# Altium schematic sheet Control.SchDoc
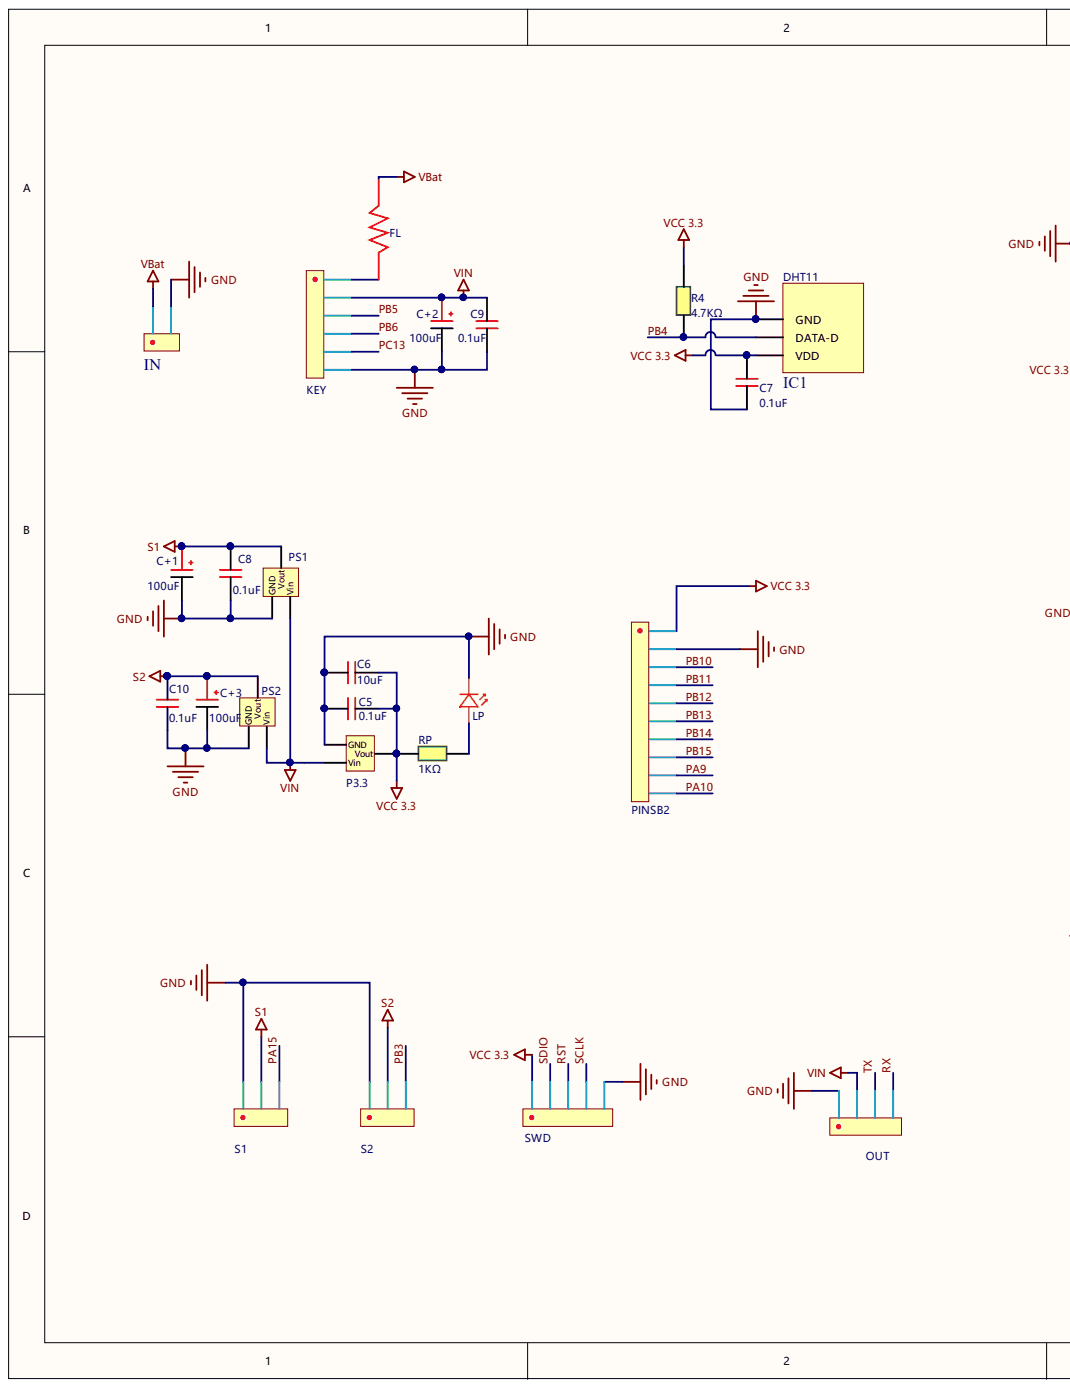
[diagram p0: Control.SchDoc, left]
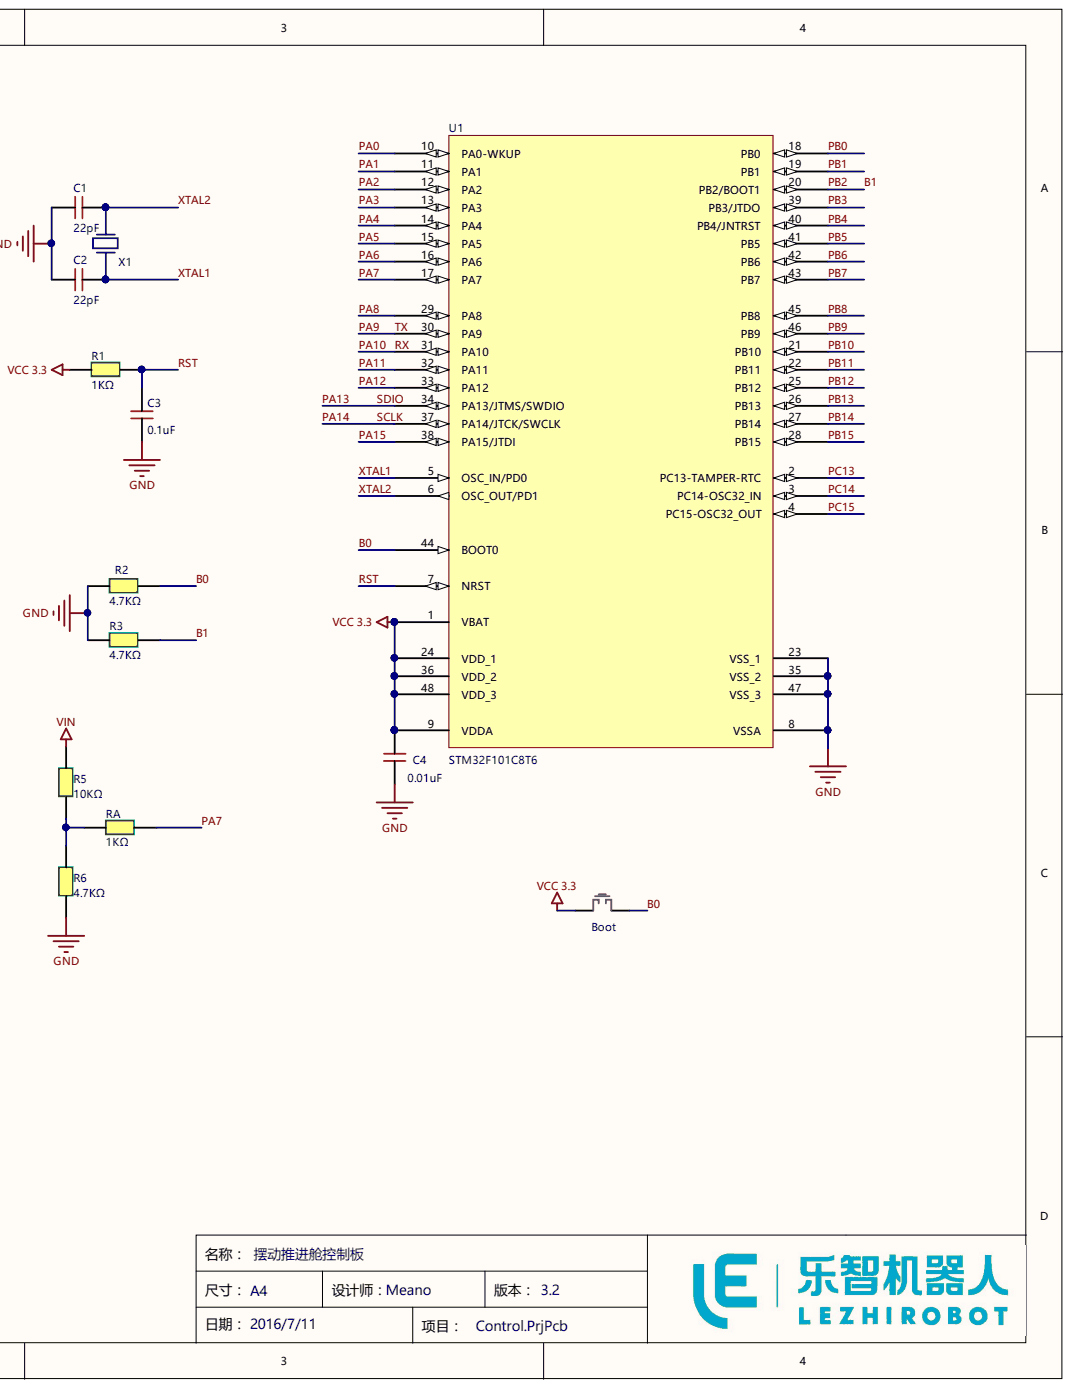
[diagram p1: Control.SchDoc, right]

## Parts
Boot  Key  [KEY]  (value not drawn)
C1  value 22pF  [Cap - 0805]  (hidden comment: Cap)
C2  value 22pF  [Cap - 0805]  (hidden comment: Cap)
C3  value 0.1uF  [Cap - 0805]  (hidden comment: Cap)
C4  value 0.01uF  [Cap - 0805]  (hidden comment: Cap)
C5  value 0.1uF  [Cap - 0805]  (hidden comment: Cap)
C6  value 10uF  [Cap - 0805]  (hidden comment: Cap)
C7  value 0.1uF  [Cap - 0805]  (hidden comment: Cap)
C8  value 0.1uF  [Cap - 0805]  (hidden comment: Cap)
C9  value 0.1uF  [Cap - 0805]  (hidden comment: Cap)
C10  value 0.1uF  [Cap - 0805]  (hidden comment: Cap)
C+1  value 100uF  [SMD-EC-F6.3]  (hidden comment: CapPlus)
C+2  value 100uF  [SMD-EC-F6.3]  (hidden comment: CapPlus)
C+3  value 100uF  [SMD-EC-F6.3]  (hidden comment: CapPlus)
DHT11  IC1  [DHT11]
FL  Fuse  [Fuse-1812]  (value not drawn)
IN  PINS2  [PINS2-Bat]  (value not drawn)
KEY  PH2.0-6P  [PH2.0-6P]  (value not drawn)
LP  LED Light  [LED - 0805]  (value not drawn)
OUT  PH2.0-4P  [PH2.0-4P]  (value not drawn)
P3.3  PowerIC  [ASM1117]  (value not drawn)
PINSB2  PINS10  [PINS10-2mm -SMD]  (value not drawn)
PS1  PowerIC  [ASM1117]  (value not drawn)
PS2  PowerIC  [ASM1117]  (value not drawn)
R1  value 1KΩ  [Res - 0805]  (hidden comment: Res)
R2  value 4.7KΩ  [Res - 0805]  (hidden comment: Res)
R3  value 4.7KΩ  [Res - 0805]  (hidden comment: Res)
R4  value 4.7KΩ  [Res - 0805]  (hidden comment: Res)
R5  value 10KΩ  [Res - 0805]  (hidden comment: Res)
R6  value 4.7KΩ  [Res - 0805]  (hidden comment: Res)
RA  value 1KΩ  [Res - 0805]  (hidden comment: Res)
RP  value 1KΩ  [Res - 0805]  (hidden comment: Res)
S1  PH2.0-3P  [PH2.0-3P]  (value not drawn)
S2  PH2.0-3P  [PH2.0-3P]  (value not drawn)
SWD  PH2.0-5P  [PH2.0-5P]  (value not drawn)
U1  STM32F101C8T6  [LQFP48_N]
X1  XTAL  [XTAL SMD]  (value not drawn)

## Nets
GND: C1.2 C2.2 C3.1 C4.1 C5.1 C6.1 C7.1 C8.2 C9.2 C10.1 C+1.2 C+2.2 C+3.2 DHT11.4 IN.2 KEY.6 LP.2 OUT.1 P3.3.1 PINSB2.2 PS1.1 PS2.1 R2.1 R3.1 R6.1 S1.1 S2.1 SWD.5 U1.8(VSSA) U1.23(VSS_1) U1.35(VSS_2) U1.47(VSS_3)
S1: C8.1 C+1.1 PS1.2 S1.2
S2: C10.2 C+3.1 PS2.2 S2.2
VBAT: FL.2 IN.1
VCC 3.3: Boot.2 C4.2 C5.2 C6.2 C7.2 DHT11.1 P3.3.2 PINSB2.1 R1.1 R4.2 RP.2 SWD.1 U1.1(VBAT) U1.9(VDDA) U1.24(VDD_1) U1.36(VDD_2) U1.48(VDD_3)
VIN: C9.1 C+2.1 KEY.2 OUT.2 P3.3.3 PS1.3 PS2.3 R5.2
B0: Boot.1 R2.2 U1.44(BOOT0)
B1: R3.2 U1.20(PB2/BOOT1)
PA0: U1.10(PA0-WKUP)
PA1: U1.11(PA1)
PA2: U1.12(PA2)
PA3: U1.13(PA3)
PA4: U1.14(PA4)
PA5: U1.15(PA5)
PA6: U1.16(PA6)
PA7: RA.2 U1.17(PA7)
PA8: U1.29(PA8)
PA9: OUT.3 PINSB2.9 U1.30(PA9)
PA10: OUT.4 PINSB2.10 U1.31(PA10)
PA11: U1.32(PA11)
PA12: U1.33(PA12)
PA13: SWD.2 U1.34(PA13/JTMS/SWDIO)
PA14: SWD.4 U1.37(PA14/JTCK/SWCLK)
PA15: S1.3 U1.38(PA15/JTDI)
PB0: U1.18(PB0)
PB1: U1.19(PB1)
PB3: S2.3 U1.39(PB3/JTDO)
PB4: DHT11.2 R4.1 U1.40(PB4/JNTRST)
PB5: KEY.3 U1.41(PB5)
PB6: KEY.4 U1.42(PB6)
PB7: U1.43(PB7)
PB8: U1.45(PB8)
PB9: U1.46(PB9)
PB10: PINSB2.3 U1.21(PB10)
PB11: PINSB2.4 U1.22(PB11)
PB12: PINSB2.5 U1.25(PB12)
PB13: PINSB2.6 U1.26(PB13)
PB14: PINSB2.7 U1.27(PB14)
PB15: PINSB2.8 U1.28(PB15)
PC13: KEY.5 U1.2(PC13-TAMPER-RTC)
PC14: U1.3(PC14-OSC32_IN)
PC15: U1.4(PC15-OSC32_OUT)
RST: C3.2 R1.2 SWD.3 U1.7(NRST)
XTAL1: C2.1 U1.5(OSC_IN/PD0) X1.1
XTAL2: C1.1 U1.6(OSC_OUT/PD1) X1.2
NetFL_1: FL.1 KEY.1
NetLP_1: LP.1 RP.1
NetR5_1: R5.1 R6.2 RA.1

## Library data of the parts
Boot = Key: Key2; Component Kind: Standard; Library Name: SmartTuna-ESchLib.SchLib
C1-C10 = Cap: Cap Normal; Component Kind: Standard; Library Name: SmartTuna-ESchLib.SchLib
C+1-C+3 = Cap+: Component Kind: Standard; Description: Cap+; Library Name: SmartTuna-ESchLib.SchLib
DHT11 = DHT11: Component Kind: Standard; Library Name: SmartTuna-ESchLib.SchLib
LP = LED: Component Kind: Standard; Library Name: SmartTuna-ESchLib.SchLib
P3.3 = ASM1117 - 3.3: PowerControl; Component Kind: Standard; Library Name: SmartTuna-ESchLib.SchLib
PS1 PS2 = ASM1117 - 5.0: PowerControl; Component Kind: Standard; Library Name: SmartTuna-ESchLib.SchLib
U1 = STM32F101C8T6: STM32 ARM-based 32-bit MCU with 64 Kbytes Flash, 48-pin LQFP, Industrial Temperature; Component Kind: Standard; ComponentLink1Description: Manufacturer Link; ComponentLink1URL: www.st.com/stm32; ComponentLink2Description: Datasheet; ComponentLink2URL: http://www.st.com/stonline/products/literature/ds/13586.pdf; DatasheetVersion: rev6, Mar-2008; PackageDescription: 48-Pin Low-Profile Quad Flat Pack, 7 x 7 mm Body, 0.5 mm Pitch (LQFP48); PackageReference: LQFP48; PackageVersion: Mar-2008; Published: 30-May-2008; Library Name: SmartTuna-ESchLib.SchLib; Publisher: Altium Limited
FL = Fuse: Component Kind: Standard; Library Name: SmartTuna-ESchLib.SchLib
IN = PINS2: Component Kind: Standard; Description: PINS2; Library Name: SmartTuna-ESchLib.SchLib
KEY = PH2.0-6P: Component Kind: Standard; Description: PH2.0-6P; Library Name: SmartTuna-ESchLib.SchLib
OUT = PH2.0-4P: Component Kind: Standard; Description: PH2.0-4P; Library Name: SmartTuna-ESchLib.SchLib
PINSB2 = PINS10: Component Kind: Standard; Description: PINS10; Library Name: SmartTuna-ESchLib.SchLib
R1-R6 RA RP = Res: Component Kind: Standard; Description: Res; Library Name: SmartTuna-ESchLib.SchLib
S1 S2 = PH2.0-3P: Component Kind: Standard; Description: PH2.0-3P; Library Name: SmartTuna-ESchLib.SchLib
SWD = PH2.0-5P: Component Kind: Standard; Description: PH2.0-5P; Library Name: SmartTuna-ESchLib.SchLib
X1 = XTAL: Component Kind: Standard; Description: XTAL; Library Name: SmartTuna-ESchLib.SchLib
